# Altium schematic sheet Brushed DC.SchDoc
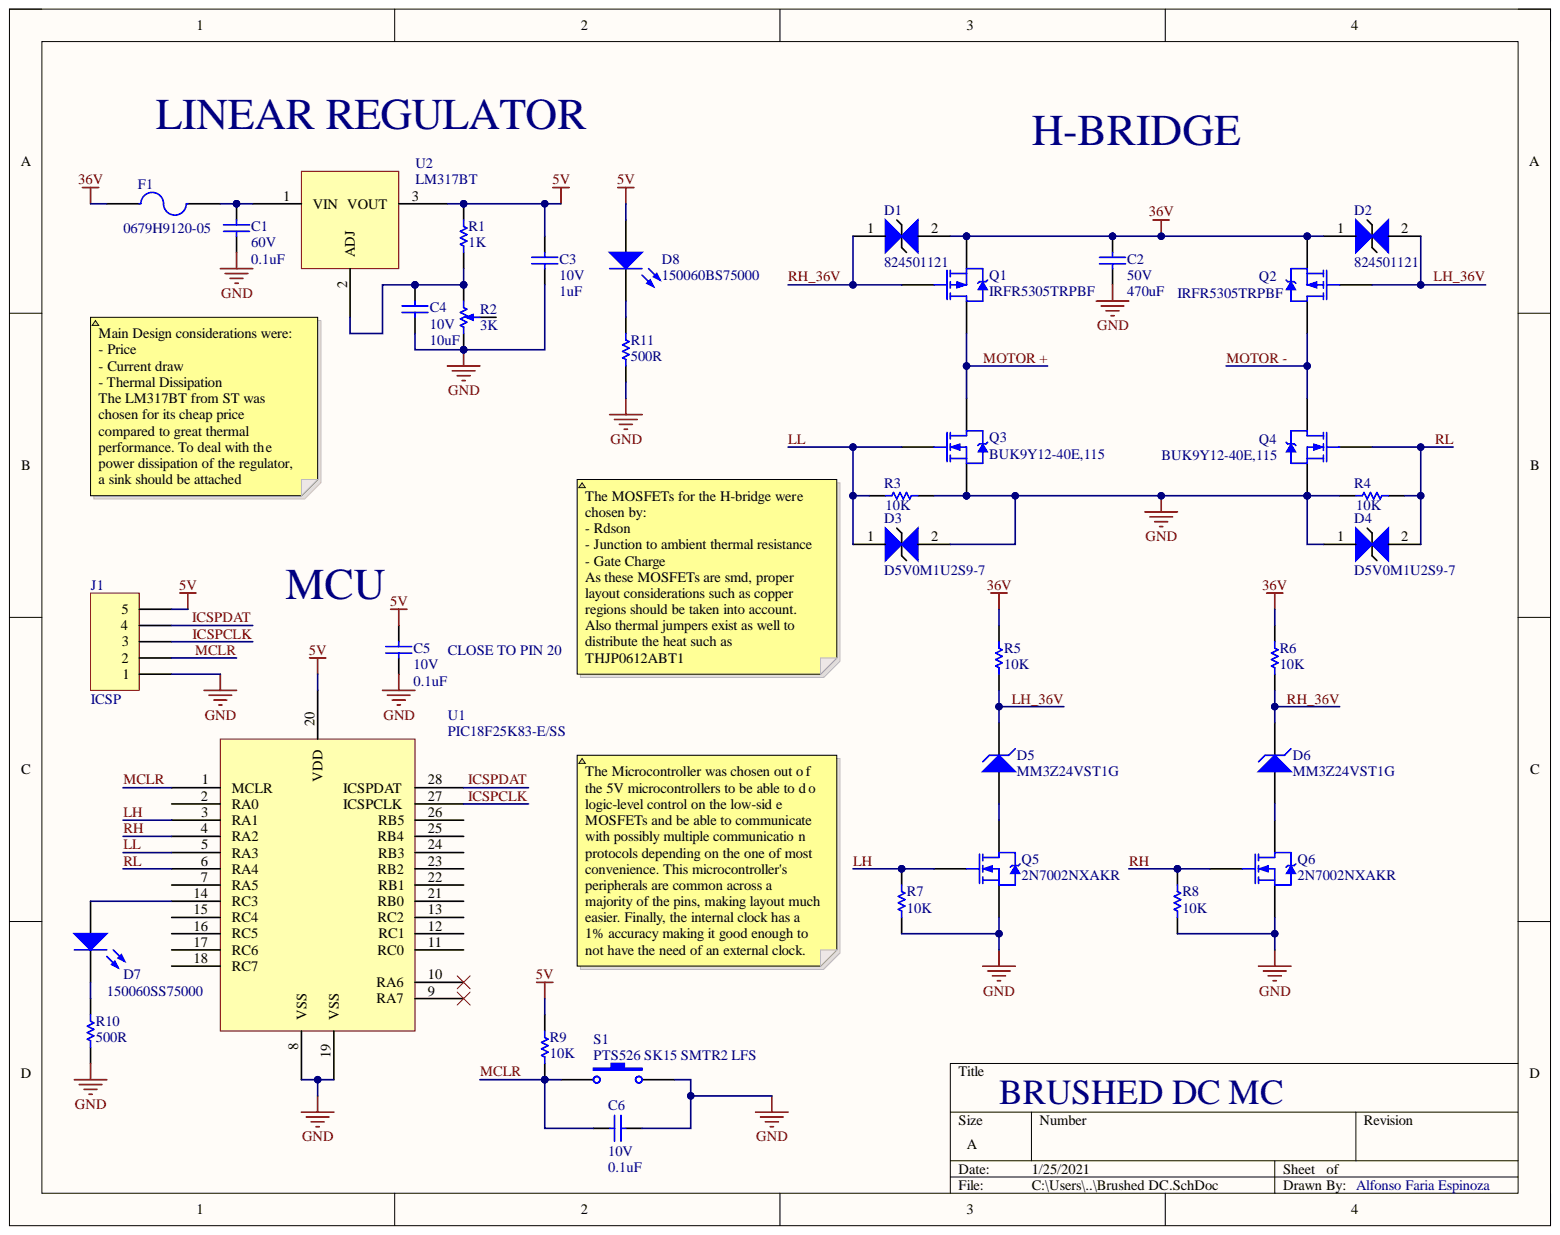
[diagram p0: Brushed DC.SchDoc]

## Parts
C1  60V  value 0.1uF  [RAD-0.3]
C2  50V  value 470uF  [RAD-0.3]
C3  10V  value 1uF  [RAD-0.3]
C4  10V  value 10uF  [RAD-0.3]
C5  10V  value 0.1uF  [RAD-0.3]
C6  10V  value 0.1uF  [RAD-0.3]
D1  824501121
D2  824501121
D3  D5V0M1U2S9-7
D4  D5V0M1U2S9-7
D5  MM3Z24VST1G  [DIODE-0.7]
D6  MM3Z24VST1G  [DIODE-0.7]
D7  150060SS75000  [LED-0]
D8  150060BS75000  [LED-0]
F1  0679H9120-05  [PIN-W2/E2.8]
J1  ICSP  [HDR1X5]
Q1  IRFR5305TRPBF  [TO-220AB]
Q2  IRFR5305TRPBF  [TO-220AB]
Q3  BUK9Y12-40E,115  [TO-220AB]
Q4  BUK9Y12-40E,115  [TO-220AB]
Q5  2N7002NXAKR  [TO-220AB]
Q6  2N7002NXAKR  [TO-220AB]
R1  value 1K  [AXIAL-0.3]  (hidden comment: Res1)
R2  3K  [POT4MM-2]
R3  value 10K  [AXIAL-0.3]  (hidden comment: Res1)
R4  value 10K  [AXIAL-0.3]  (hidden comment: Res1)
R5  value 10K  [AXIAL-0.3]  (hidden comment: Res1)
R6  value 10K  [AXIAL-0.3]  (hidden comment: Res1)
R7  value 10K  [AXIAL-0.3]  (hidden comment: Res1)
R8  value 10K  [AXIAL-0.3]  (hidden comment: Res1)
R9  value 10K  [AXIAL-0.3]  (hidden comment: Res1)
R10  value 500R  [AXIAL-0.3]  (hidden comment: Res1)
R11  value 500R  [AXIAL-0.3]  (hidden comment: Res1)
S1  PTS526 SK15 SMTR2 LFS  [SPST-2]
U1  PIC18F25K83-E/SS
U2  LM317BT

## Nets
5V: C3.2 C5.2 D8.1 J1.5 R1.2 R9.2 U1.20(VDD) U2.3
36V: C2.2 D1.2 D2.1 F1.1 Q1.3 Q2.3 R5.2 R6.2
GND: C1.1 C2.1 C3.1 C4.1 C5.1 C6.1 D3.2 D4.1 J1.1 Q3.3 Q4.3 Q5.3 Q6.3 R2.CCW R3.2 R4.1 R7.1 R8.1 R10.1 R11.1 S1.2 U1.8(VSS) U1.19(VSS)
ICSPCLK: J1.3 U1.27(ICSPCLK)
ICSPDAT: J1.4 U1.28(ICSPDAT)
LH: Q5.1 R7.2 U1.3(RA1)
LH_36V: D2.2 D5.2 Q2.1 R5.1
LL: D3.1 Q3.1 R3.1 U1.5(RA3)
MCLR: C6.2 J1.2 R9.1 S1.1 U1.1(MCLR)
MOTOR +: Q1.2 Q3.2
MOTOR -: Q2.2 Q4.2
RH: Q6.1 R8.2 U1.4(RA2)
RH_36V: D1.1 D6.2 Q1.1 R6.1
RL: D4.2 Q4.1 R4.2 U1.6(RA4)
NetC1_2: C1.2 F1.2 U2.1
NetC4_2: C4.2 R1.1 R2.CW U2.2
NetD5_1: D5.1 Q5.2
NetD6_1: D6.1 Q6.2
NetD7_1: D7.1 U1.14(RC3)
NetD7_2: D7.2 R10.2
NetD8_2: D8.2 R11.2

Not connected: R2 W; U1 2(RA0) 7(RA5) 9(RA7) 10(RA6) 11(RC0) 12(RC1) 13(RC2) 15(RC4) 16(RC5) 17(RC6) 18(RC7) 21(RB0) 22(RB1) 23(RB2) 24(RB3) 25(RB4) 26(RB5)

## Library data of the parts
C1-C6 = Cap: Capacitor; Component Kind: Standard; LatestRevisionDate: 17-Jul-2002; LatestRevisionNote: Re-released for DXP Platform.; Library Name: Miscellaneous Devices.IntLib; PackageDescription: Radial Cap, Thru-Hole; 2 Leads; 0.3 in Pin Spacing; PackageReference: RAD-0.3; Published: 8-Jun-2000; Signal Integrity: Cap; Simulation: CAP; Publisher: Altium Limited
D1-D4 = TVS: Component Kind: Standard; Library Name: Brushed DC.SchLib
D5 D6 = D Zener: Zener Diode; Component Kind: Standard; LatestRevisionDate: 17-Jul-2002; LatestRevisionNote: Re-released for DXP Platform.; Library Name: Miscellaneous Devices.IntLib; PackageDescription: Diode, Thru-Hole; 2 Leads; 0.7 in Pin Spacing; PackageReference: DIODE-0.7; Published: 8-Jun-2000; Signal Integrity: D Zener; Simulation: ZENER; Publisher: Altium Limited
D7 D8 = LED0: Typical INFRARED GaAs LED; Component Kind: Standard; LatestRevisionDate: 08-Jul-2005; LatestRevisionNote: Mech Layer 1 removed.; Library Name: Miscellaneous Devices.IntLib; PackageDescription: LED; 2 Leads; PackageReference: LED-0; Published: 8-Jun-2000; Simulation: LED0; Publisher: Altium Limited
F1 = Fuse 2: Fuse; Component Kind: Standard; LatestRevisionDate: 17-Jul-2002; LatestRevisionNote: Re-released for DXP Platform.; Library Name: Miscellaneous Devices.IntLib; PackageDescription: Thru-Hole; 2 Leads; PackageReference: PIN-W2/E2.8; Published: 8-Jun-2000; Simulation: FUSE; Publisher: Altium Limited
J1 = Header 5: Header, 5-Pin; Component Kind: Standard; LatestRevisionDate: 17-Jul-2002; LatestRevisionNote: Re-released for DXP Platform.; Library Name: Miscellaneous Connectors.IntLib; Signal Integrity: Connector; Publisher: Altium Limited
Q1 Q2 = PMOS-2: P-Channel Power MOSFET; Note: Pin 4 not drawn and electrically connected to Pin 2.; Component Kind: Standard; ComponentLink1Description: Manufacturer Link; ComponentLink1URL: http://www.irf.com/; DatasheetVersion: PD-9.390D; LatestRevisionDate: 17-Jul-2002; LatestRevisionNote: Re-released for DXP Platform.; Library Name: Miscellaneous Devices.IntLib; PackageDescription: TO, Thru-Hole, Vertical, Heatsink Mounted; 3 In-Line Leads; Pitch 2.54 mm; PackageReference: TO-220AB; PackageVersion: Feb-1997; Published: 8-Jun-2000; Simulation: IRF9510; Publisher: Altium Limited
Q3-Q6 = NMOS-2: N-Channel Power MOSFET; Note: Pin 2 is electrically connected to pin 4.; Component Kind: Standard; ComponentLink1Description: Manufacturer Link; ComponentLink1URL: http://www.irf.com/; DatasheetVersion: PD-9.814; LatestRevisionDate: 17-Jul-2002; LatestRevisionNote: Re-released for DXP Platform.; Library Name: Miscellaneous Devices.IntLib; PackageDescription: TO, Thru-Hole, Vertical, Heatsink Mounted; 3 In-Line Leads; Pitch 2.54 mm; PackageReference: TO-220AB; PackageVersion: Feb-1997; Published: 8-Jun-2000; Simulation: IRF1010; Publisher: Altium Limited
R1 R3-R11 = Res1: Resistor; Component Kind: Standard; LatestRevisionDate: 17-Jul-2002; LatestRevisionNote: Re-released for DXP Platform.; Library Name: Miscellaneous Devices.IntLib; PackageDescription: Axial Device, Thru-Hole; 2 Leads; 0.3 in Pin Spacing; PackageReference: AXIAL-0.3; Published: 8-Jun-2000; Signal Integrity: Res; Simulation: RESISTOR; Publisher: Altium Limited
R2 = RPot SM: Square Trimming Potentiometer; Component Kind: Standard; ComponentLink1Description: Manufacturer Link; ComponentLink1URL: http://bourns.com/2/search.html; DatasheetVersion: Oct-2003; Library Name: Miscellaneous Devices.IntLib; PackageDescription: Square Trimming Potentiometer; PackageReference: POT4MM-2; Published: 17-Dec-2003; Publisher: Altium Limited
S1 = SW-PB: Switch; Component Kind: Standard; LatestRevisionDate: 17-Jul-2002; LatestRevisionNote: Re-released for DXP Platform.; Library Name: Miscellaneous Devices.IntLib; PackageDescription: Switch; 2 Leads; PackageReference: SPST-2; Published: 24-Mar-1999; Publisher: Altium Limited
U1 = PIC18F25K83-E/SS: PIC PIC® XLP™ 18K, Functional Safety (FuSa) Microcontroller IC 8-Bit 64MHz 32KB (16K x 16) FLASH 28-SSOP; Component Kind: Standard; Library Name: Brushed DC.SchLib
U2 = LM317BT: Linear Voltage Regulator IC 1 Output 1.5A TO-220; Component Kind: Standard; Library Name: Brushed DC.SchLib
